# Altium schematic sheet AT32-U2.SCHDOC(AT32-U2)
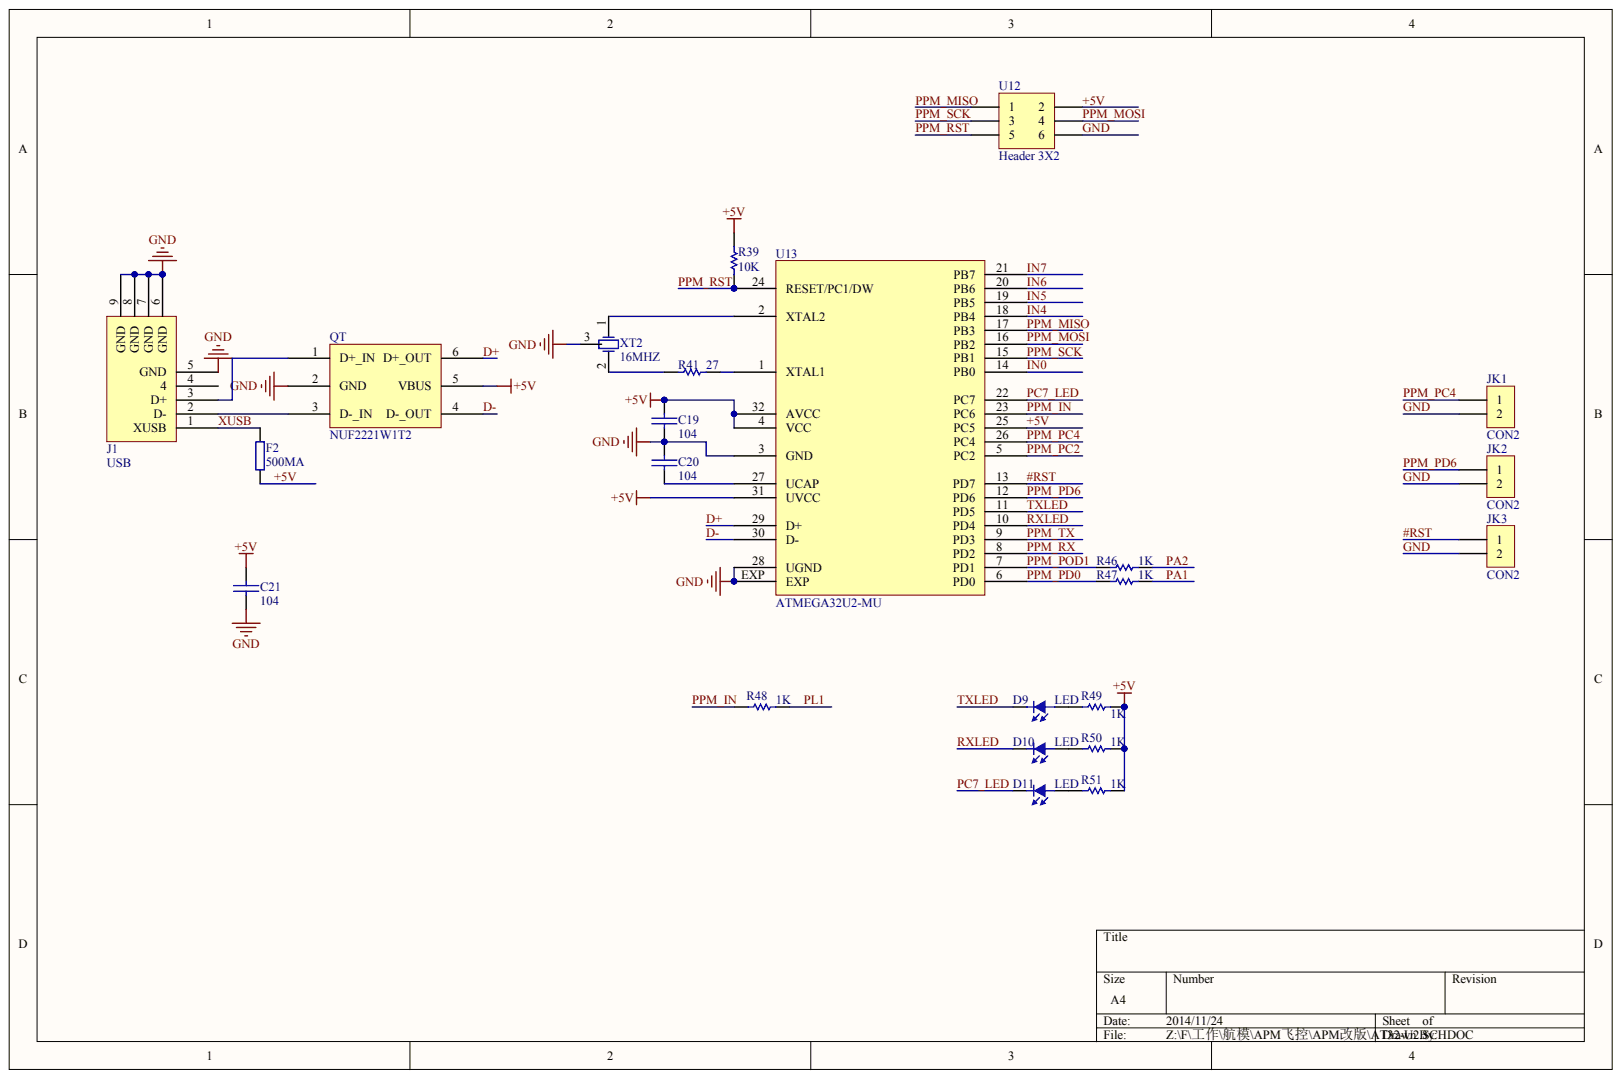
[diagram p0: AT32-U2.SCHDOC]

## Parts
C19  104
C20  104
C21  104
D9  LED
D10  LED
D11  LED
F2  500MA
J1  USB
JK1  CON2
JK2  CON2
JK3  CON2
QT  NUF2221W1T2
R39  10K
R41  27
R46  1K
R47  1K
R48  1K
R49  1K
R50  1K
R51  1K
U12  Header 3X2
U13  ATMEGA32U2-MU
XT2  16MHZ

## Nets
#RST: JK3.1 U13.13(PD7)
+5V: C19.2 C21.1 F2.1 QT.5(VBUS) R39.2 R49.2 R50.2 R51.2 U12.2 U13.4(VCC) U13.25(PC5) U13.31(UVCC) U13.32(AVCC)
D+: QT.6(D+_OUT) U13.29(D+)
D-: QT.4(D-_OUT) U13.30(D-)
GND: C19.1 C20.1 C21.2 J1.5(GND) J1.6(GND) J1.7(GND) J1.8(GND) J1.9(GND) JK1.2 JK2.2 JK3.2 QT.2(GND) U12.6 U13.3(GND) U13.28(UGND) U13.EXP XT2.3
IN0: U13.14(PB0)
IN4: U13.18(PB4)
IN5: U13.19(PB5)
IN6: U13.20(PB6)
IN7: U13.21(PB7)
PA1: R47.2
PA2: R46.2
PC7_LED: D11.2 U13.22(PC7)
PL1: R48.2
PPM_IN: R48.1 U13.23(PC6)
PPM_MISO: U12.1 U13.17(PB3)
PPM_MOSI: U12.4 U13.16(PB2)
PPM_PC2: U13.5(PC2)
PPM_PC4: JK1.1 U13.26(PC4)
PPM_PD0: R47.1 U13.6(PD0)
PPM_PD6: JK2.1 U13.12(PD6)
PPM_POD1: R46.1 U13.7(PD1)
PPM_RST: R39.1 U12.5 U13.24(RESET/PC1/DW)
PPM_RX: U13.8(PD2)
PPM_SCK: U12.3 U13.15(PB1)
PPM_TX: U13.9(PD3)
RXLED: D10.2 U13.10(PD4)
TXLED: D9.2 U13.11(PD5)
XUSB: F2.2 J1.1(XUSB)
NetC20_2: C20.2 U13.27(UCAP)
NetD9_1: D9.1 R49.1
NetD10_1: D10.1 R50.1
NetD11_1: D11.1 R51.1
NetJ1_2: J1.2(D-) QT.3(D-_IN)
NetJ1_3: J1.3(D+) QT.1(D+_IN)
NetR41_1: R41.1 XT2.2
NetR41_2: R41.2 U13.1(XTAL1)
NetU13_2: U13.2(XTAL2) XT2.1

Not connected: J1 4
